# Altium schematic sheet [04]-RS485.SchDoc
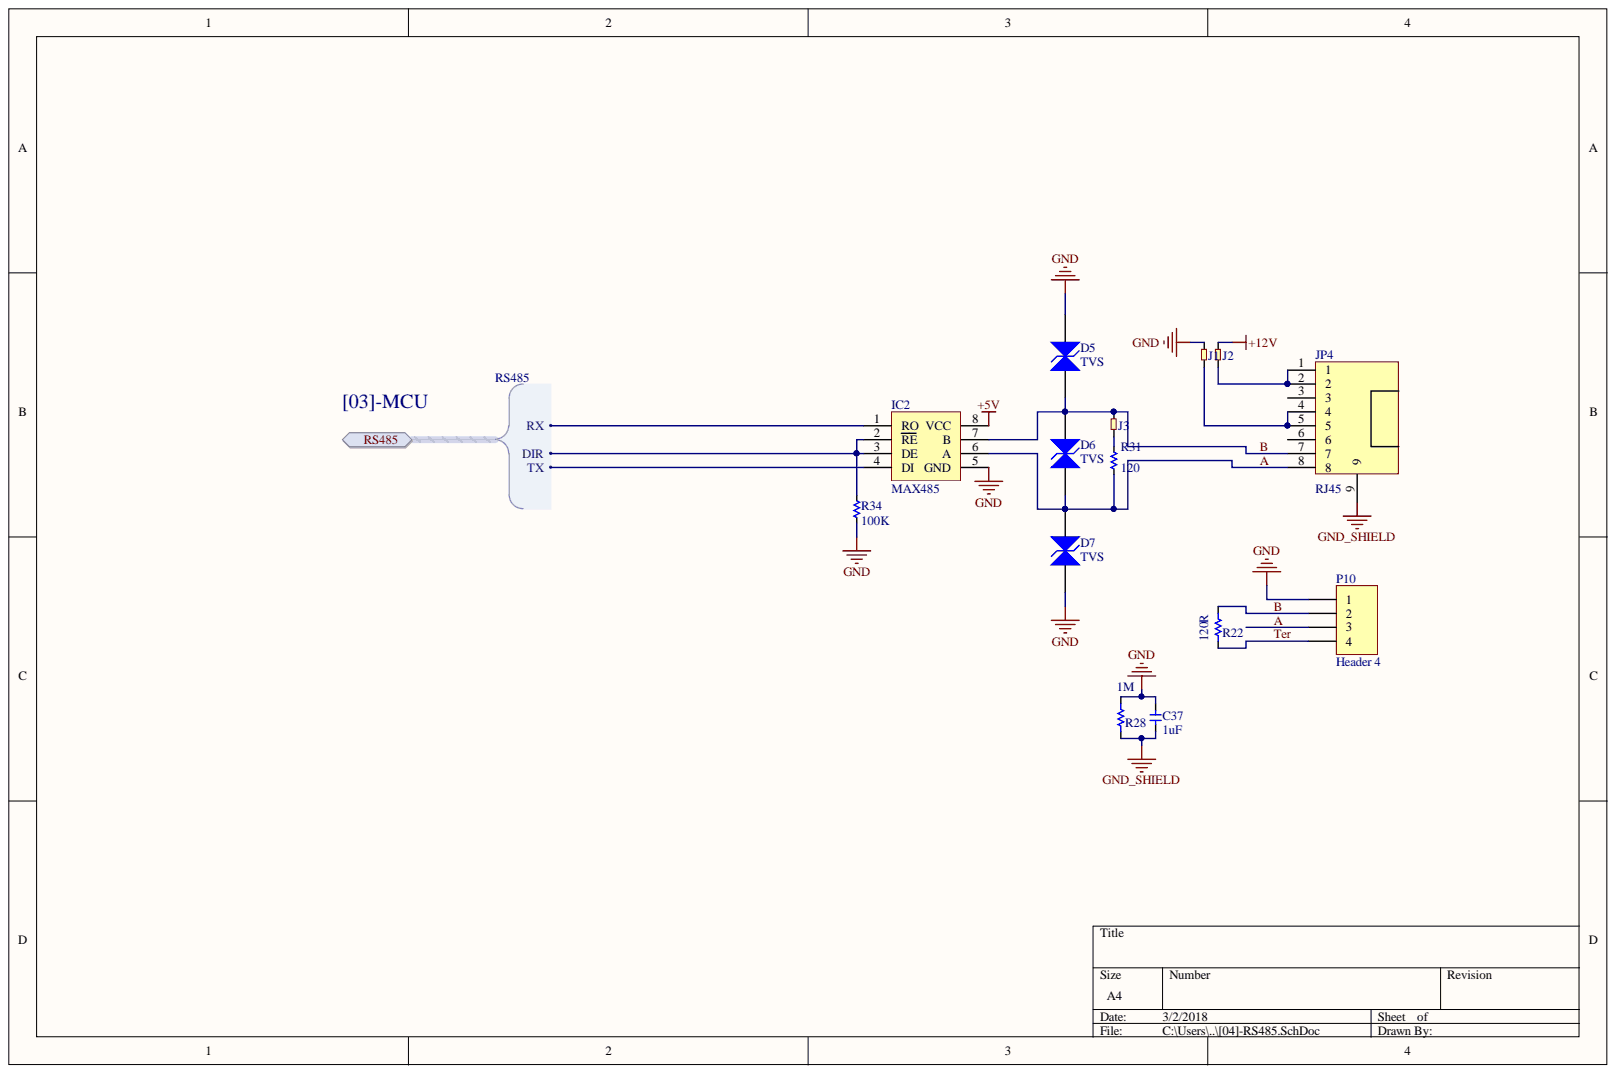
[diagram p0: [04]-RS485.SchDoc]

## Parts
C37  1uF  [cap-0805]
D5  TVS  [diode-SMB]
D6  TVS  [diode-SMB]
D7  TVS  [diode-SMB]
IC2  MAX485  [SO8]
J1  Jump-2P  [Jump-2P]  (value not drawn)
J2  Jump-2P  [Jump-2P]  (value not drawn)
J3  Jump-2P  [Jump-2P]  (value not drawn)
JP4  RJ45  [RJ45_8PIN_VOSAT_V2]
P10  Header 4  [TBlock4-5.08 ST]
R22  120R  [res-0805]
R28  1M  [res-0805]
R31  120  [res-0805]
R34  100K  [res-0603]

## Ports
RS485, RS485.DIR, RS485.RX, RS485.TX

## Nets
+5V: IC2.8(VCC)
+12V: J2.2
GND: C37.2 D5.2 D7.1 IC2.5(GND) J1.2 P10.1 R28.2 R34.2
GND_SHIELD: C37.1 JP4.9 R28.1
A: D6.1 D7.2 IC2.6(A) JP4.8 P10.3 R31.1
B: D5.1 D6.2 IC2.7(B) J3.2 JP4.7 P10.2 R22.2
TER: P10.4 R22.1
NetIC2_1: IC2.1(RO) ; port RS485
NetIC2_2: IC2.2(RE) IC2.3(DE) R34.1 ; port RS485
NetIC2_4: IC2.4(DI) ; port RS485
NetJ1_1: J1.1 JP4.4 JP4.5
NetJ2_1: J2.1 JP4.1 JP4.2
NetJ3_1: J3.1 R31.2

Not connected: JP4 3 6

## Library data of the parts
C37 = Cap-0805: Capacitor (Semiconductor SIM Model); Component Kind: Standard; LatestRevisionDate: 29-May-2009; LatestRevisionNote: IPC-7351 Footprint Added.; PackageDescription: Chip Capacitor; PackageReference: C1206; Published: 8-Jun-2000; Library Name: THUVIENTUTAO.lib; Publisher: Altium Limited
D5-D7 = TVS_DIODE: Component Kind: Standard; Library Name: THUVIENTUTAO.lib
IC2 = MAX485: RS232 - RS485 (Same sn75176); Component Kind: Standard; Library Name: Library.SchLib
P10 = Header 4: Header, 4-Pin; Component Kind: Standard; LatestRevisionDate: 17-Jul-2002; LatestRevisionNote: Re-released for DXP Platform.; Library Name: THUVIENTUTAO.lib; Publisher: Altium Limited
R22 R28 = Res-0805: Resistor; Component Kind: Standard; LatestRevisionDate: 17-Jul-2002; LatestRevisionNote: Re-released for DXP Platform.; PackageDescription: Axial Device, Thru-Hole; 2 Leads; 0.3 in Pin Spacing; PackageReference: AXIAL-0.3; Published: 8-Jun-2000; Library Name: THUVIENTUTAO.lib; Publisher: Altium Limited
R31 R34 = Res-SMD: Resistor; Manufacturer: Generic Components; Class: Passive; Component Kind: Standard; Library Name: LIBRARY.IntLib; Published: 08/06/2000; Publisher: Altium Hobart Technology Centre
J1-J3 = Jump-2P: Component Kind: Standard; Library Name: THUVIENTUTAO.lib
JP4 = RJ45: Component Kind: Standard; Library Name: Library_HoangAnh.SchLib
